# Altium schematic sheet 01_Power.SchDoc(01_Power)
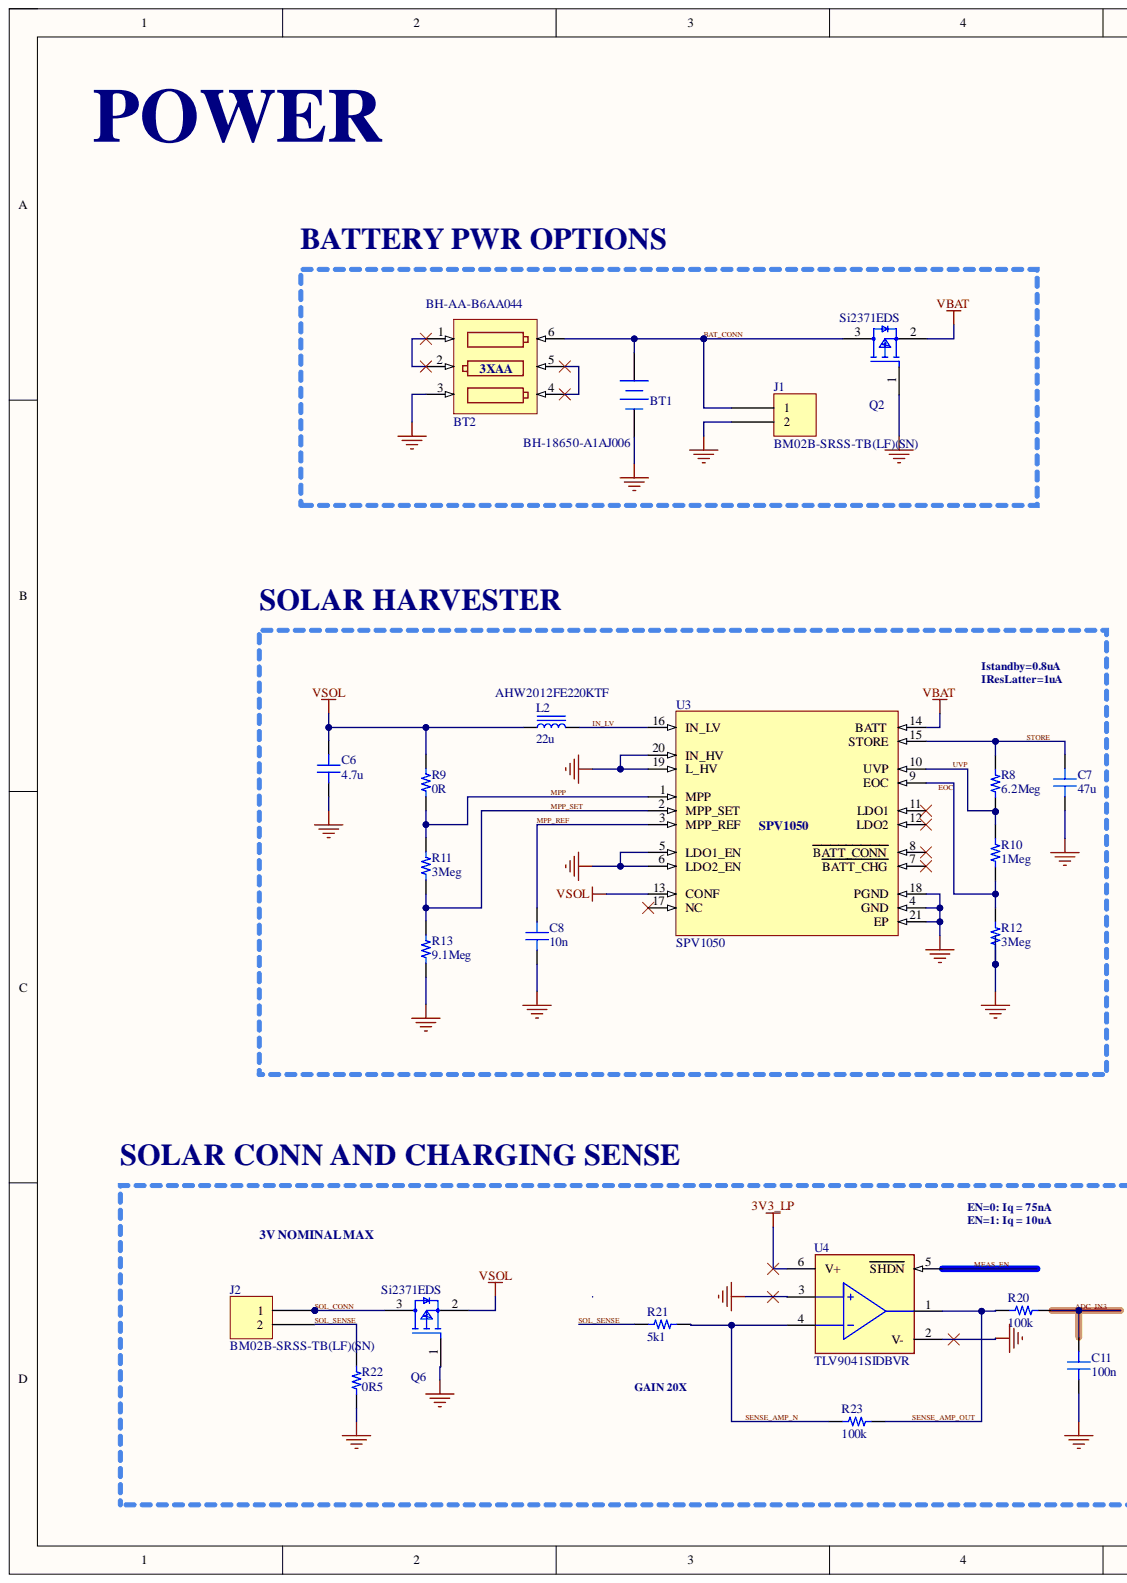
[diagram p0: 01_Power.SchDoc, left]
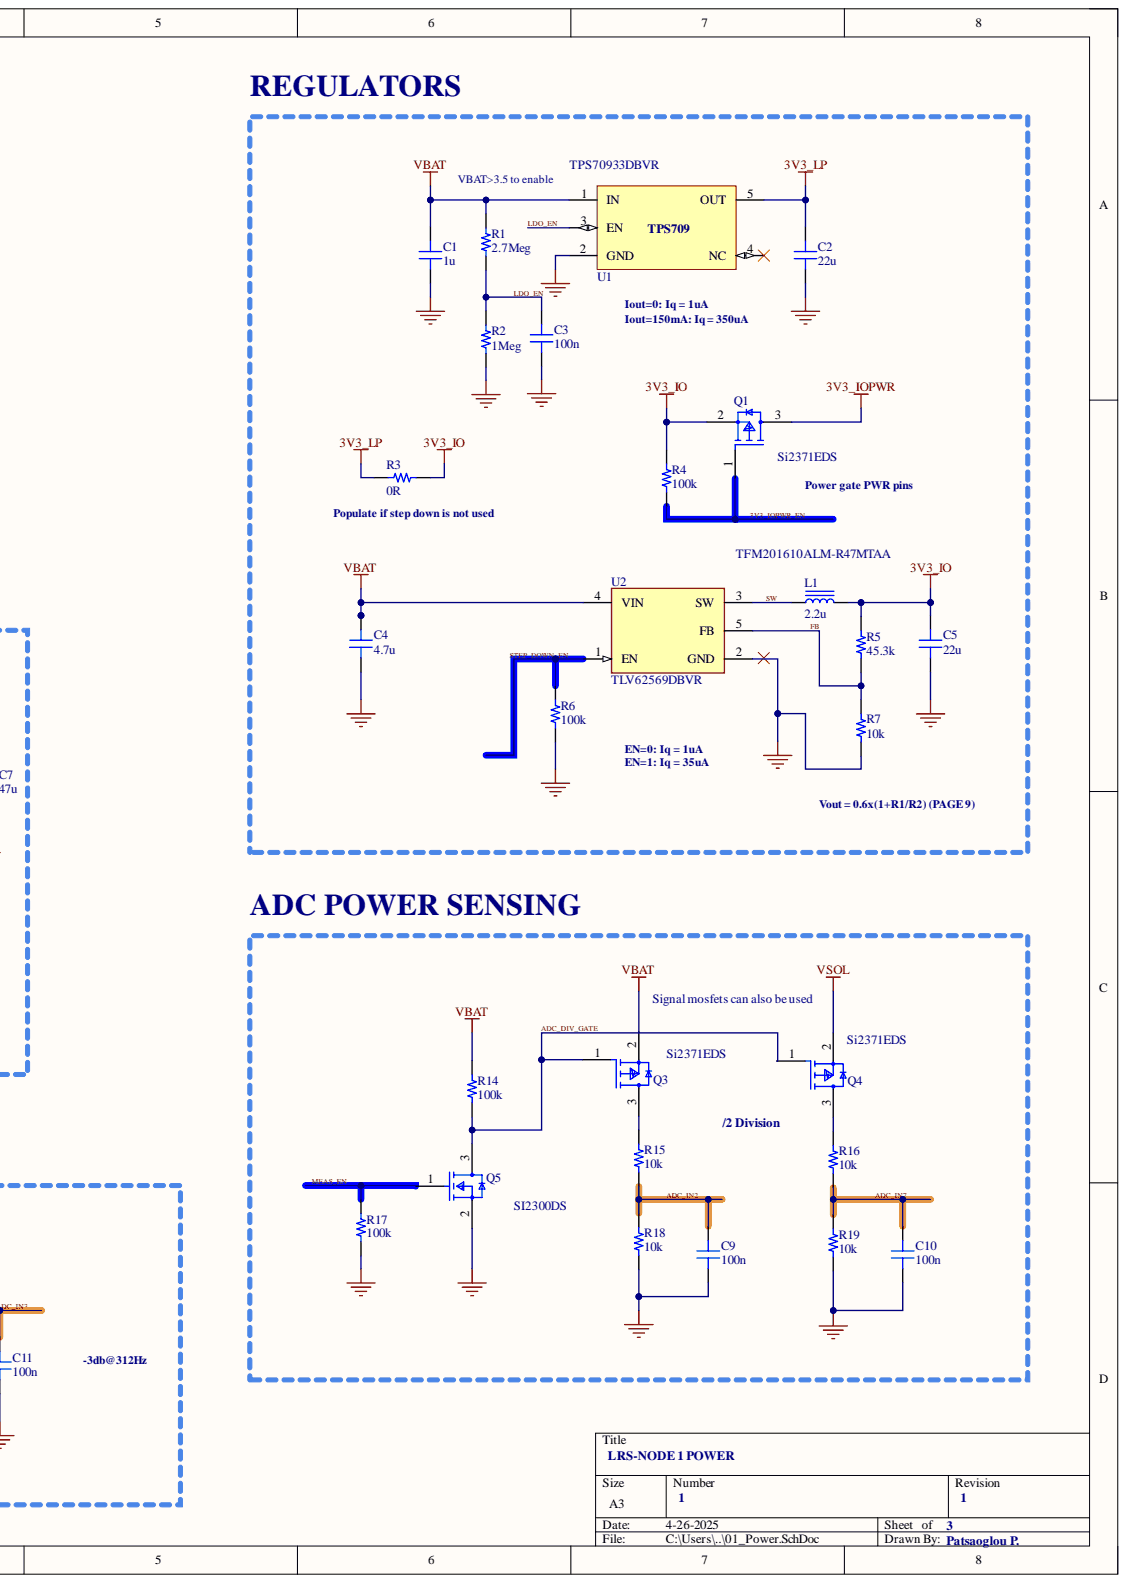
[diagram p1: 01_Power.SchDoc, right]

## Parts
BT1  BH-18650-A1AJ006  [BH-18650-A1AJ006]
BT2  BH-AA-B6AA044  [BH-AA-B6AA044]
C1  1u  [CAP 0805_2012]
C2  22u  [CAP 0805_2012]
C3  100n  [CAP 0603_1608]
C4  4.7u  [CAP 0805_2012]
C5  22u  [CAP 0805_2012]
C6  4.7u  [CAP 0805_2012]
C7  47u  [CAP 0805_2012]
C8  10n  [CAP 0603_1608]
C9  100n  [CAP 0603_1608]
C10  100n  [CAP 0603_1608]
C11  100n  [CAP 0603_1608]
J1  BM02B-SRSS-TB(LF)(SN)  [JST BM02B-SRSS-TB]
J2  BM02B-SRSS-TB(LF)(SN)  [JST BM02B-SRSS-TB]
L1  2.2u  [IND 0806_2016]
L2  22u  [IND 0806_2016]
Q1  Si2371EDS  [SOT23]
Q2  Si2371EDS  [SOT23]
Q3  Si2371EDS  [SOT23]
Q4  Si2371EDS  [SOT23]
Q5  SI2300DS  [SOT23]
Q6  Si2371EDS  [SOT23]
R1  2.7Meg  [RES 0603_1608]
R2  1Meg  [RES 0603_1608]
R3  0R  [RES 0603_1608]
R4  100k  [RES 0603_1608]
R5  45.3k  [RES 0603_1608]
R6  100k  [RES 0603_1608]
R7  10k  [RES 0603_1608]
R8  6.2Meg  [RES 0603_1608]
R9  0R  [RES 0603_1608]
R10  1Meg  [RES 0603_1608]
R11  3Meg  [RES 0603_1608]
R12  3Meg  [RES 0603_1608]
R13  9.1Meg  [RES 0603_1608]
R14  100k  [RES 0603_1608]
R15  10k  [RES 0603_1608]
R16  10k  [RES 0603_1608]
R17  100k  [RES 0603_1608]
R18  10k  [RES 0603_1608]
R19  10k  [RES 0603_1608]
R20  100k  [RES 0603_1608]
R21  5k1  [RES 0603_1608]
R22  0R5  [RES 0603_1608]
R23  100k  [RES 0603_1608]
U1  TPS70933DBVR  [TPS70933DBVR]
U2  TLV62569DBVR  [FP-DBV0005A-MFG]
U3  SPV1050  [SPV1050]
U4  TLV9041SIDBVR  [FP-DBV0006A-MFG]

## Nets
3V3_IO: C5.2 L1.2 Q1.2 R3.2 R4.2 R5.2
3V3_IOPWR: Q1.3
3V3_LP: C2.2 R3.1 U1.5(OUT) U4.6(V+)
GND: BT1.2 BT2.3 C1.1 C2.1 C3.1 C4.1 C5.1 C6.1 C7.1 C8.1 C9.1 C10.1 C11.1 J1.2 Q2.1 Q5.2 Q6.1 R2.1 R6.1 R7.1 R12.1 R13.1 R17.1 R18.1 R19.1 R22.1 U1.2(GND) U2.2(GND) U3.4(GND) U3.5(LDO1_EN) U3.6(LDO2_EN) U3.18(PGND) U3.19(L_HV) U3.20(IN_HV) U3.21(EP) U4.2(V-) U4.3
VBAT: C1.2 C4.2 Q2.2 Q3.2 R1.2 R14.2 U1.1(IN) U2.4(VIN) U3.14(BATT)
VSOL: C6.2 L2.1 Q4.2 Q6.2 R9.2 U3.13(CONF)
3V3_IOPWR_EN: Q1.1 R4.1
ADC_DIV_GATE: Q3.1 Q4.1 Q5.3 R14.1
ADC_IN2: C9.2 R15.1 R18.2
ADC_IN3: C11.2 R20.1
ADC_IN7: C10.2 R16.1 R19.2
BAT_CONN: BT1.1 BT2.6 J1.1 Q2.3
EOC: R10.1 R12.2 U3.9(EOC)
FB: R5.1 R7.2 U2.5(FB)
IN_LV: L2.2 U3.16(IN_LV)
LDO_EN: C3.2 R1.1 R2.2 U1.3(EN)
MEAS_EN: Q5.1 R17.2 U4.5(SHDN)
MPP: R9.1 R11.2 U3.1(MPP)
MPP_REF: C8.2 U3.3(MPP_REF)
MPP_SET: R11.1 R13.2 U3.2(MPP_SET)
SENSE_AMP_N: R21.1 R23.2 U4.4
SENSE_AMP_OUT: R20.2 R23.1 U4.1
SOL_CONN: J2.1 Q6.3
SOL_SENSE: J2.2 R21.2 R22.2
STEP_DOWN_EN: R6.2 U2.1(EN)
STORE: C7.2 R8.2 U3.15(STORE)
SW: L1.1 U2.3(SW)
UVP: R8.1 R10.2 U3.10(UVP)
NetBT2_1: BT2.1 BT2.2
NetBT2_4: BT2.4 BT2.5(3XAA)
NetQ3_3: Q3.3 R15.2
NetQ4_3: Q4.3 R16.2

Not connected: U1 4(NC); U3 7(BATT_CHG) 8(BATT_CONN) 11(LDO1) 12(LDO2) 17(NC)

## Library data of the parts
BT1 = BH-18650-A1AJ006: MYOUNG BH-18650-A1AJ006 Lion 18650 battery holder LCSC: C5290175; Component Kind: Standard; Library Name: TL-LRNODE.SchLib
BT2 = BH-AA-B6AA044: MYOUNG BH-AA-B6AA044 3xAA battery holder LCSC: C19184082; Component Kind: Standard; Library Name: TL-LRNODE.SchLib
C1 C2 C4-C7 = 0805YD105KAT2A: CAP CER 1UF 16V X5R 0805; manufacturer: AVX Corporation; Capacitance: 1µF; Database Table Name: Capacitors - Ceramic - 0805; Tolerance: ±10%; Applications: General Purpose; Component Kind: Standard; componentlink1description: Datasheet; componentlink1url: http://datasheets.avx.com/cx5r.pdf; componentlink2description: DigiKey Link; componentlink2url: http://digikey.com/product-detail/en/avx-corporation/0805YD105KAT2A/478-1411-1-ND/564443; Device Package: 0805 (2012 Metric); lastupdated: 02-Sep-20 1:04:13 AM; Library Name: Celestial Altium Library - altium_library.DbLib; Lifecycle Status: Active; Minimum Order: 1; Mounting Type: Surface Mount, MLCC; Operating Temperature: -55°C ~ 85°C; Package / Case: 0805 (2012 Metric); packaging: TapeAndReel; Part Number: 0805YD105KAT2A; Part Status: Active; partid: 16241; price: 0.06; series: -; Size / Dimension: 0.079" L x 0.049" W (2.01mm x 1.25mm); Supplier 1: DigiKey; Supplier Part Number 1: 478-1411-1-ND; Temperature Coefficient: X5R; Thickness (Max): 0.055" (1.40mm); Voltage - Rated: 16V
  C1: Value: 1u
  C2 C5: Value: 22u
  C4 C6: Value: 4.7u
  C7: Value: 47u
C3 C8-C11 = 0603YC104KAT2A: CAP CER 0.1UF 16V X7R 0603; manufacturer: AVX Corporation; Capacitance: 0.1µF; Database Table Name: Capacitors - Ceramic - 0603; Tolerance: ±10%; Applications: General Purpose; Component Kind: Standard; componentlink1description: Datasheet; componentlink1url: http://datasheets.avx.com/X7RDielectric.pdf; componentlink2description: DigiKey Link; componentlink2url: http://digikey.com/product-detail/en/avx-corporation/0603YC104KAT2A/478-1239-1-ND/564271; Device Package: 0603 (1608 Metric); lastupdated: 02-Sep-20 1:03:30 AM; Library Name: Celestial Altium Library - altium_library.DbLib; Lifecycle Status: Active; Minimum Order: 1; Mounting Type: Surface Mount, MLCC; Operating Temperature: -55°C ~ 125°C; Package / Case: 0603 (1608 Metric); packaging: TapeAndReel; Part Number: 0603YC104KAT2A; Part Status: Active; partid: 5664; price: 0.02; series: -; Size / Dimension: 0.063" L x 0.032" W (1.60mm x 0.81mm); Supplier 1: DigiKey; Supplier Part Number 1: 478-1239-1-ND; Temperature Coefficient: X7R; Thickness (Max): 0.035" (0.90mm); Voltage - Rated: 16V
  C3 C9-C11: Value: 100n
  C8: Value: 10n
J1 J2 = BM02B-SRSS-TB(LF)(SN): CONN HEADER SMD 2POS 1MM; Manufacturer: JST Sales America Inc.; Current Rating (Amps): 0.7A; Database Table Name: Connectors - Rectangular Headers Male Pins; Material Flammability Rating: UL94 V-0; Number of Positions: 2; Number of Positions Loaded: All; Pitch - Mating: 0.039" (1.00mm); Shrouding: Shrouded - 4 Wall; Style: Board to Cable/Wire; Voltage Rating: 50V; Component Kind: Standard; ComponentLink1Description: Datasheet; ComponentLink1URL: http://www.jst-mfg.com/product/pdf/eng/eSR.pdf; ComponentLink2Description: DigiKey Link; ComponentLink2URL: http://digikey.com/product-detail/en/jst-sales-america-inc/BM02B-SRSS-TB-LF-SN/455-1788-1-ND/926859; Connector Type: Header; Contact Finish - Mating: Tin; Contact Finish - Post: Tin; Contact Material: Copper Alloy; Contact Shape: Square; Contact Type: Male Pin; Fastening Type: Push-Pull; Features: Solder Retention; Insulation Color: Natural; Insulation Height: 0.167" (4.25mm); Insulation Material: Polyamide (PA), Nylon; LastUpdated: 12-Jan-20 10:11:04 PM; Library Name: Celestial Altium Library - altium_library.DbLib; Lifecycle Status: Active; Minimum Order: 1; Mounting Type: Surface Mount; Number of Rows: 1; Operating Temperature: -25°C ~ 85°C; Packaging: TapeAndReel; Part Number: BM02B-SRSS-TB(LF)(SN); Part Status: Active; PartId: 12; Price: 0.22; Series: SR; Supplier 1: DigiKey; Supplier Part Number 1: 455-1788-1-ND; Termination: Solder
L1 L2 = AHP 201610FA-1R0M: 1 UH SHIELDED DRUM CORE, WIREWOU; Manufacturer: TAI-TECH Advanced Electronics Co., Ltd.; Current Rating (Amps): 3.4 A; Database Table Name: Inductors - Power; DC Resistance (DCR): 60mOhm Max; Inductance: 1 µH; Inductance Frequency - Test: 1 MHz; Shielding: Unshielded; Tolerance: ±20%; Value: 1 µH; Component Kind: Standard; ComponentLink1Description: Datasheet; ComponentLink1URL: https://media.digikey.com/pdf/Data%20Sheets/Tai%20Tech%20PDFs/AHP%20Series.pdf; ComponentLink2Description: DigiKey Link; ComponentLink2URL: https://www.digikey.com/en/products/detail/tai-tech-advanced-electronics-co-ltd/AHP-201610FA-1R0M/17835811; Device Package: 0806 (2016 Metric); Height - Seated (Max): 0.039" (1.00mm); LastUpdated: 03-Jun-24 8:14:02 PM; Library Name: Celestial Altium Library - altium_library.DbLib; Lifecycle Status: Active; Minimum Order: 1; Mounting Type: Surface Mount; Operating Temperature: -40°C ~ 125°C; Package / Case: 0806 (2016 Metric); Packaging: TapeAndReel; Part Number: AHP 201610FA-1R0M; Part Status: Active; PartId: 6393; Price: 0.07; Series: AHP201610FA; Size / Dimension: 0.079" L x 0.063" W (2.00mm x 1.60mm); Supplier 1: DigiKey; Supplier Device Package: 0806; Supplier Part Number 1: 4816-AHP201610FA-1R0MCT-ND
Q1-Q4 Q6 = P-CHANNEL: Component Kind: Standard; Library Name: TL-LRNODE.SchLib
Q5 = N-CHANNEL: Component Kind: Standard; Library Name: TL-LRNODE.SchLib
R1-R23 = RMEF0603ZT0R00: RES 0 OHM JUMPER 0603; Manufacturer: Stackpole Electronics Inc; Database Table Name: Resistors - Surface Mount - 0603; Ratings: 7C1 RoHS w/out Exemption (100% Pb-Free), AEC-Q200; Resistance: 0 Ohms; Component Kind: Standard; ComponentLink1Description: Datasheet; ComponentLink1URL: https://www.seielect.com/Catalog/SEI-RMEF.pdf; ComponentLink2Description: DigiKey Link; ComponentLink2URL: https://www.digikey.com/en/products/detail/stackpole-electronics-inc/RMEF0603ZT0R00/16839754; Composition: Thick Film; Device Package: 0603 (1608 Metric); Features: Automotive AEC-Q200; Height - Seated (Max): 0.020" (0.50mm); LastUpdated: 09-Feb-24 8:41:04 PM; Library Name: Celestial Altium Library - altium_library.DbLib; Lifecycle Status: Active; Minimum Order: 1; Number of Terminations: 2; Operating Temperature: -55°C ~ 155°C; Package / Case: 0603 (1608 Metric); Packaging: TapeAndReel; Part Number: RMEF0603ZT0R00; Part Status: Active; PartId: 69501; Price: 0.01; Series: RMEF; Size / Dimension: 0.063" L x 0.031" W (1.60mm x 0.80mm); Supplier 1: DigiKey; Supplier Device Package: 0603; Supplier Part Number 1: 738-RMEF0603ZT0R00CT-ND; Tolerance: Jumper
  R1: Value: 2.7Meg
  R2 R10: Value: 1Meg
  R3 R9: Value: 0R
  R4 R6 R14 R17 R20 R23: Value: 100k
  R5: Value: 45.3k
  R7 R15 R16 R18 R19: Value: 10k
  R8: Value: 6.2Meg
  R11 R12: Value: 3Meg
  R13: Value: 9.1Meg
  R21: Value: 5k1
  R22: Value: 0R5
U1 = TPS70933DBVR: TPS709 150-mA, 30-V, 1-µA IQ Voltage Regulators with Enable; Component Kind: Standard; Library Name: TL-LRNODE.SchLib
U2 = CMP-04918-000387-1: IC REG BUCK ADJ 2A SOT23-5; Max Input Voltage: 5.5V; Min Input Voltage: 2.5V; Min Output Voltage: 600mV; Output Configuration: Positive; Output Type: Adjustable; Switching Frequency: 1.5MHz; Synchronous Rectifier: Yes; Case/Package: SOT-23-5; Component Kind: Standard; Library Name: Altium Content Vault; Max Operating Temperature: 125°C; Max Output Voltage: 5.5V; Min Operating Temperature: -40°C; Mount: Surface Mount; Output Current: 2A; Packaging: Cut Tape; RoHS Compliant: Yes
U3 = SPV1050: STMicroelectronics SPV1050 solar power harvester; Component Kind: Standard; Library Name: TL-LRNODE.SchLib
U4 = CMP-04895-000446-1: 1.2-V ULTRA-LOW VOLTAGE, 350-KHZ; Component Kind: Standard; Library Name: Altium Content Vault
